# Altium schematic sheet CPU2560_Power54331.SchDoc
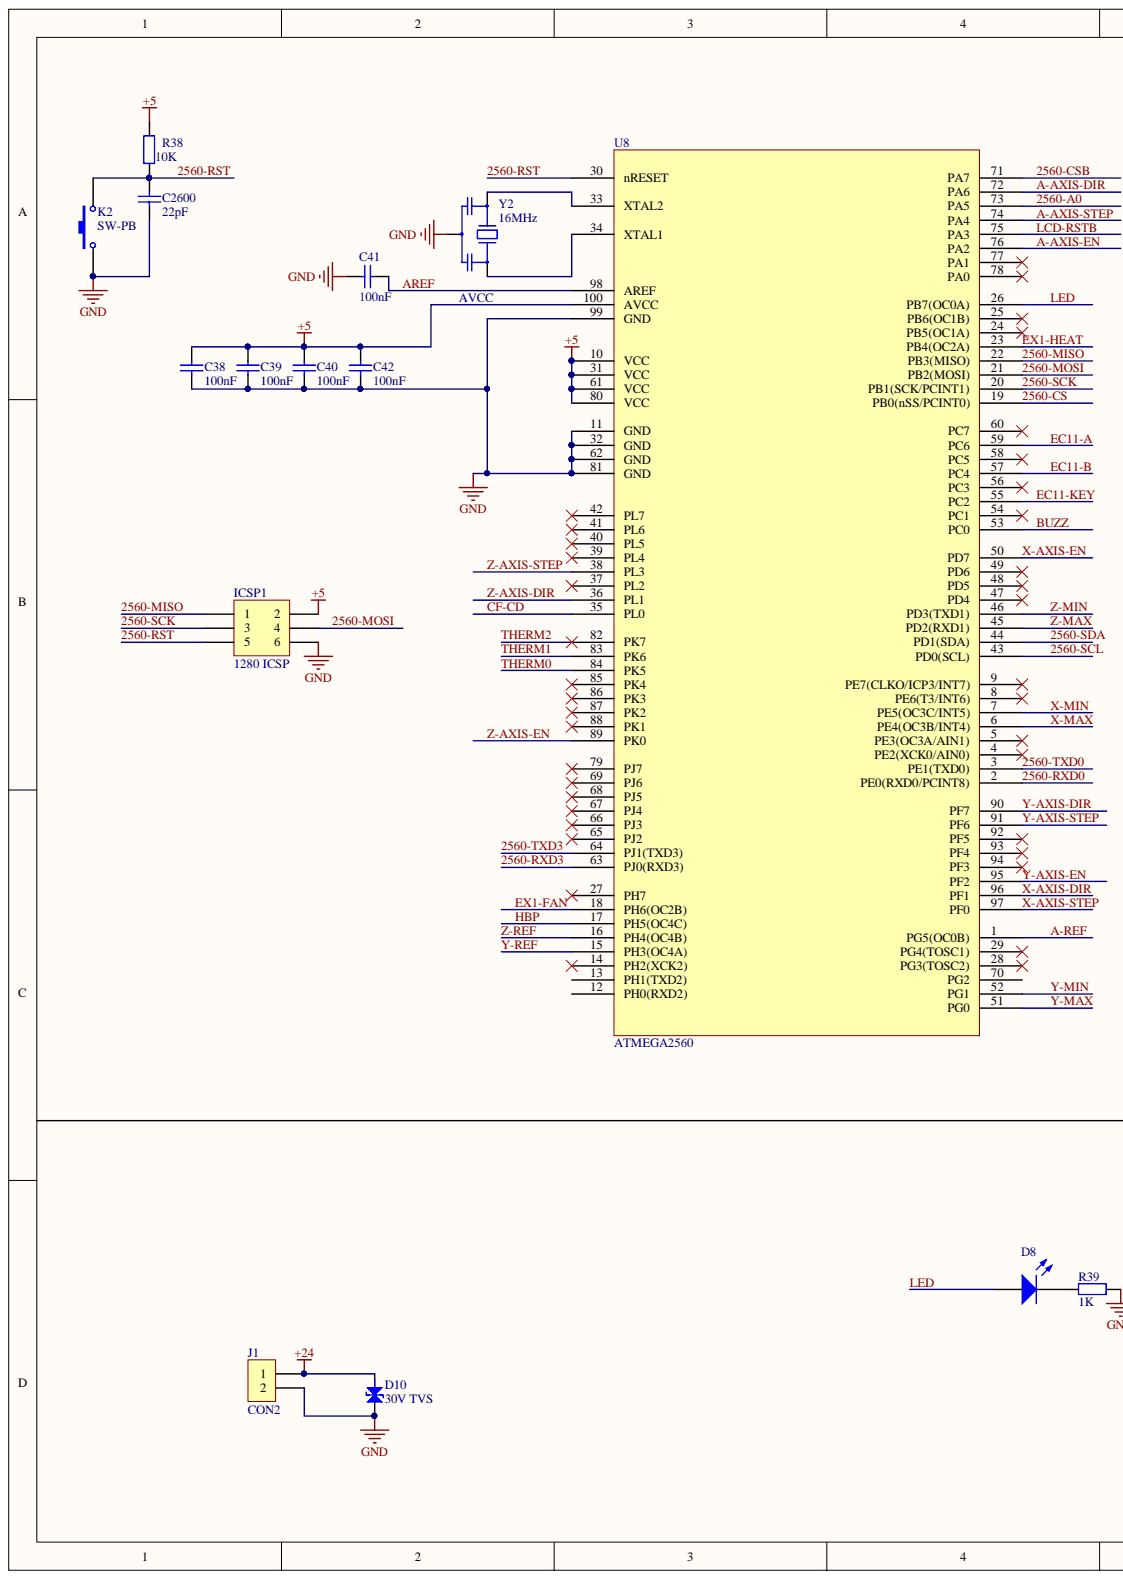
[diagram p0: CPU2560_Power54331.SchDoc, left]
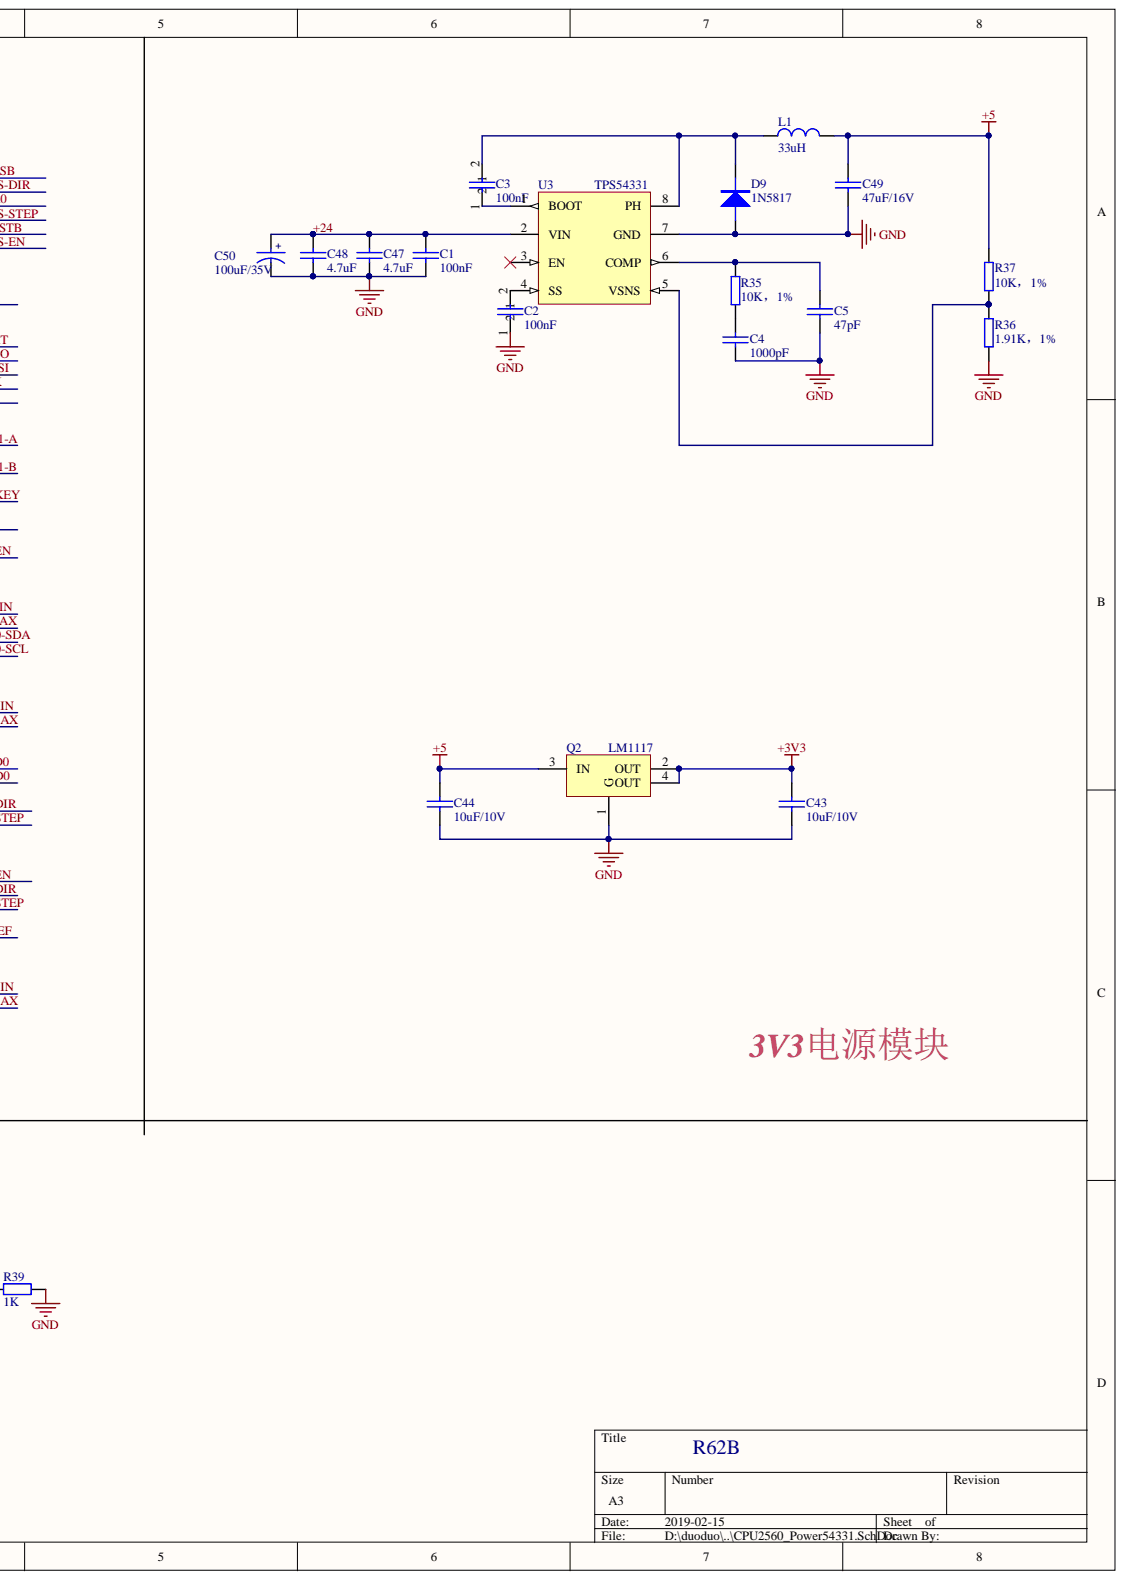
[diagram p1: CPU2560_Power54331.SchDoc, right]

## Parts
C1  100nF  [0603]
C2  100nF  [0603]
C3  100nF  [0603]
C4  1000pF  [0603]
C5  47pF  [0603]
C38  100nF  [0603]
C39  100nF  [0603]
C40  100nF  [0603]
C41  100nF  [0603]
C42  100nF  [0603]
C43  10uF/10V  [0603]
C44  10uF/10V  [0603]
C47  4.7uF  [1206]
C48  4.7uF  [1206]
C49  47uF/16V  [1206]
C50  100uF/35V  [EC-6.3]
C2600  22pF  [0603]
D8  LED3  [0603D]  (value not drawn)
D9  1N5817  [SMA]
D10  30V TVS  [SMBJ]
ICSP1  1280 ICSP  [HDR2X3]
J1  CON2  [CON2-5.0]
K2  SW-PB  [PB2-4]
L1  33uH  [L-7X7]
Q2  LM1117  [SOT-89]
R35  10K，1%  [0603]
R36  1.91K，1%  [0603]
R37  10K，1%  [0603]
R38  10K  [0603]
R39  1K  [0603]
U3  TPS54331  [LC-SO-8_3.9mm]
U8  ATMEGA2560  [TQFP-100]
Y2  16MHz  [CSTCE-V]

## Nets
+3V3: C43.2 Q2.2(OUT) Q2.4(OUT)
+5: C38.1 C39.1 C40.1 C42.2 C44.1 C49.1 ICSP1.2 L1.2 Q2.3(IN) R37.2 R38.2 U8.10(VCC) U8.31(VCC) U8.61(VCC) U8.80(VCC) U8.100(AVCC)
GND: C1.2 C2.1 C4.1 C5.1 C38.2 C39.2 C40.2 C41.1 C42.1 C43.1 C44.2 C47.2 C48.2 C49.2 C50.2 C2600.1 D9.1 D10.1 ICSP1.6 J1.2 K2.1 Q2.1(G) R36.2 R39.2 U3.7(GND) U8.11(GND) U8.32(GND) U8.62(GND) U8.81(GND) U8.99(GND) Y2.2
2560-A0: U8.73(PA5)
2560-CS: U8.19(PB0(nSS/PCINT0))
2560-CSB: U8.71(PA7)
2560-MISO: ICSP1.1 U8.22(PB3(MISO))
2560-MOSI: ICSP1.4 U8.21(PB2(MOSI))
2560-RST: C2600.2 ICSP1.5 K2.2 R38.1 U8.30(nRESET)
2560-RXD0: U8.2(PE0(RXD0/PCINT8))
2560-RXD3: U8.63(PJ0(RXD3))
2560-SCK: ICSP1.3 U8.20(PB1(SCK/PCINT1))
2560-SCL: U8.43(PD0(SCL))
2560-SDA: U8.44(PD1(SDA))
2560-TXD0: U8.3(PE1(TXD0))
2560-TXD3: U8.64(PJ1(TXD3))
+24: C1.1 C47.1 C48.1 C50.1 D10.2 J1.1 U3.2(VIN)
A-AXIS-DIR: U8.72(PA6)
A-AXIS-EN: U8.76(PA2)
A-AXIS-STEP: U8.74(PA4)
A-REF: U8.1(PG5(OC0B))
AREF: C41.2 U8.98(AREF)
BUZZ: U8.53(PC0)
CF-CD: U8.35(PL0)
EC11-A: U8.59(PC6)
EC11-B: U8.57(PC4)
EC11-KEY: U8.55(PC2)
EX1-FAN: U8.18(PH6(OC2B))
EX1-HEAT: U8.23(PB4(OC2A))
HBP: U8.17(PH5(OC4C))
LCD-RSTB: U8.75(PA3)
LED: D8.1 U8.26(PB7(OC0A))
THERM0: U8.84(PK5)
THERM1: U8.83(PK6)
THERM2: U8.82(PK7)
X-AXIS-DIR: U8.96(PF1)
X-AXIS-EN: U8.50(PD7)
X-AXIS-STEP: U8.97(PF0)
X-MAX: U8.6(PE4(OC3B/INT4))
X-MIN: U8.7(PE5(OC3C/INT5))
Y-AXIS-DIR: U8.90(PF7)
Y-AXIS-EN: U8.95(PF2)
Y-AXIS-STEP: U8.91(PF6)
Y-MAX: U8.51(PG0)
Y-MIN: U8.52(PG1)
Y-REF: U8.15(PH3(OC4A))
Z-AXIS-DIR: U8.36(PL1)
Z-AXIS-EN: U8.89(PK0)
Z-AXIS-STEP: U8.38(PL3)
Z-MAX: U8.45(PD2(RXD1))
Z-MIN: U8.46(PD3(TXD1))
Z-REF: U8.16(PH4(OC4B))
NetC2_2: C2.2 U3.4(SS)
NetC3_1: C3.1 U3.1(BOOT)
NetC3_2: C3.2 D9.2 L1.1 U3.8(PH)
NetC4_2: C4.2 R35.2
NetC5_2: C5.2 R35.1 U3.6(COMP)
NetD8_2: D8.2 R39.1
NetR36_1: R36.1 R37.1 U3.5(VSNS)
NetU8_33: U8.33(XTAL2) Y2.1
NetU8_34: U8.34(XTAL1) Y2.3

Not connected: U3 3(EN); U8 4(PE2(XCK0/AIN0)) 5(PE3(OC3A/AIN1)) 8(PE6(T3/INT6)) 9(PE7(CLKO/ICP3/INT7)) 12(PH0(RXD2)) 13(PH1(TXD2)) 14(PH2(XCK2)) 24(PB5(OC1A)) 25(PB6(OC1B)) 27(PH7) 28(PG3(TOSC2)) 29(PG4(TOSC1)) 37(PL2) 39(PL4) 40(PL5) 41(PL6) 42(PL7) 47(PD4) 48(PD5) 49(PD6) 54(PC1) 56(PC3) 58(PC5) 60(PC7) 65(PJ2) 66(PJ3) 67(PJ4) 68(PJ5) 69(PJ6) 70(PG2) 77(PA1) 78(PA0) 79(PJ7) 85(PK4) 86(PK3) 87(PK2) 88(PK1) 92(PF5) 93(PF4) 94(PF3)

## Library data of the parts
C1-C5 C43 C44 C47-C49 = CAP: Capacitor; Component Kind: Standard; Library Name: Miscellaneous Devices.SCHLIB
C38-C42 C2600 = Cap: Capacitor; Component Kind: Standard; Library Name: Miscellaneous Devices.IntLib; Signal Integrity: Cap; Simulation: CAP
C50 = CAPACITOR POL: Component Kind: Standard; Description: Capacitor Pol; Library Name: DMSch.SCHLIB
D8 = LED3: Typical BLUE SiC LED; Component Kind: Standard; DatasheetVersion: 16-Apr-2002; LatestRevisionDate: 17-Dec-2003; LatestRevisionNote: PCB Footprint replaced.; Library Name: Miscellaneous Devices.IntLib; PackageReference: 3.5X2.8X1.9; PackageVersion: 16-Apr-2002; Published: 8-Jun-2000; Signal Integrity: LED; Simulation: LED3; Publisher: Altium Limited
D9 = DIODE: Component Kind: Standard; Description: Diode; Library Name: DMSch.SCHLIB
D10 = DIODE TVS: Schottky Diode; Component Kind: Standard; Library Name: Miscellaneous Devices.SCHLIB
ICSP1 = Header 3X2: Header, 3-Pin, Dual row; Component Kind: Standard; LatestRevisionDate: 17-Jul-2002; LatestRevisionNote: Re-released for DXP Platform.; Library Name: Miscellaneous Connectors.IntLib; Signal Integrity: Connector; Publisher: Altium Limited
J1 = CON2: Connector; Component Kind: Standard; Library Name: Miscellaneous Devices.SCHLIB
K2 = SW-PB: Switch; Component Kind: Standard; LatestRevisionDate: 17-Jul-2002; LatestRevisionNote: Re-released for DXP Platform.; Library Name: Miscellaneous Devices.IntLib; PackageReference: SPST-2; Published: 24-Mar-1999; Publisher: Altium Limited
L1 = INDUCTOR: Component Kind: Standard; Library Name: Miscellaneous Devices.SCHLIB
Q2 = LM1117: LINER REG; Component Kind: Standard; Library Name: DMSch.SCHLIB
R35-R37 = RES5: Component Kind: Standard; Library Name: DMSch.SCHLIB
R38 R39 = Res2: Resistor; Component Kind: Standard; Library Name: Miscellaneous Devices.IntLib; Signal Integrity: Res; Simulation: RESISTOR
  R38: PackageReference: AXIAL-0.4
Y2 = CRYSTAL-3: Crystal; Component Kind: Standard; Library Name: DMSch.SCHLIB
U3 = TPS54331: Component Kind: Standard; Library Name: DMSch.SCHLIB
U8 = ATMEGA2560: Component Kind: Standard; Library Name: DMSch.SCHLIB
